# Altium schematic sheet [4] - Proximity,Temperature Sensors and OLED.SchDoc
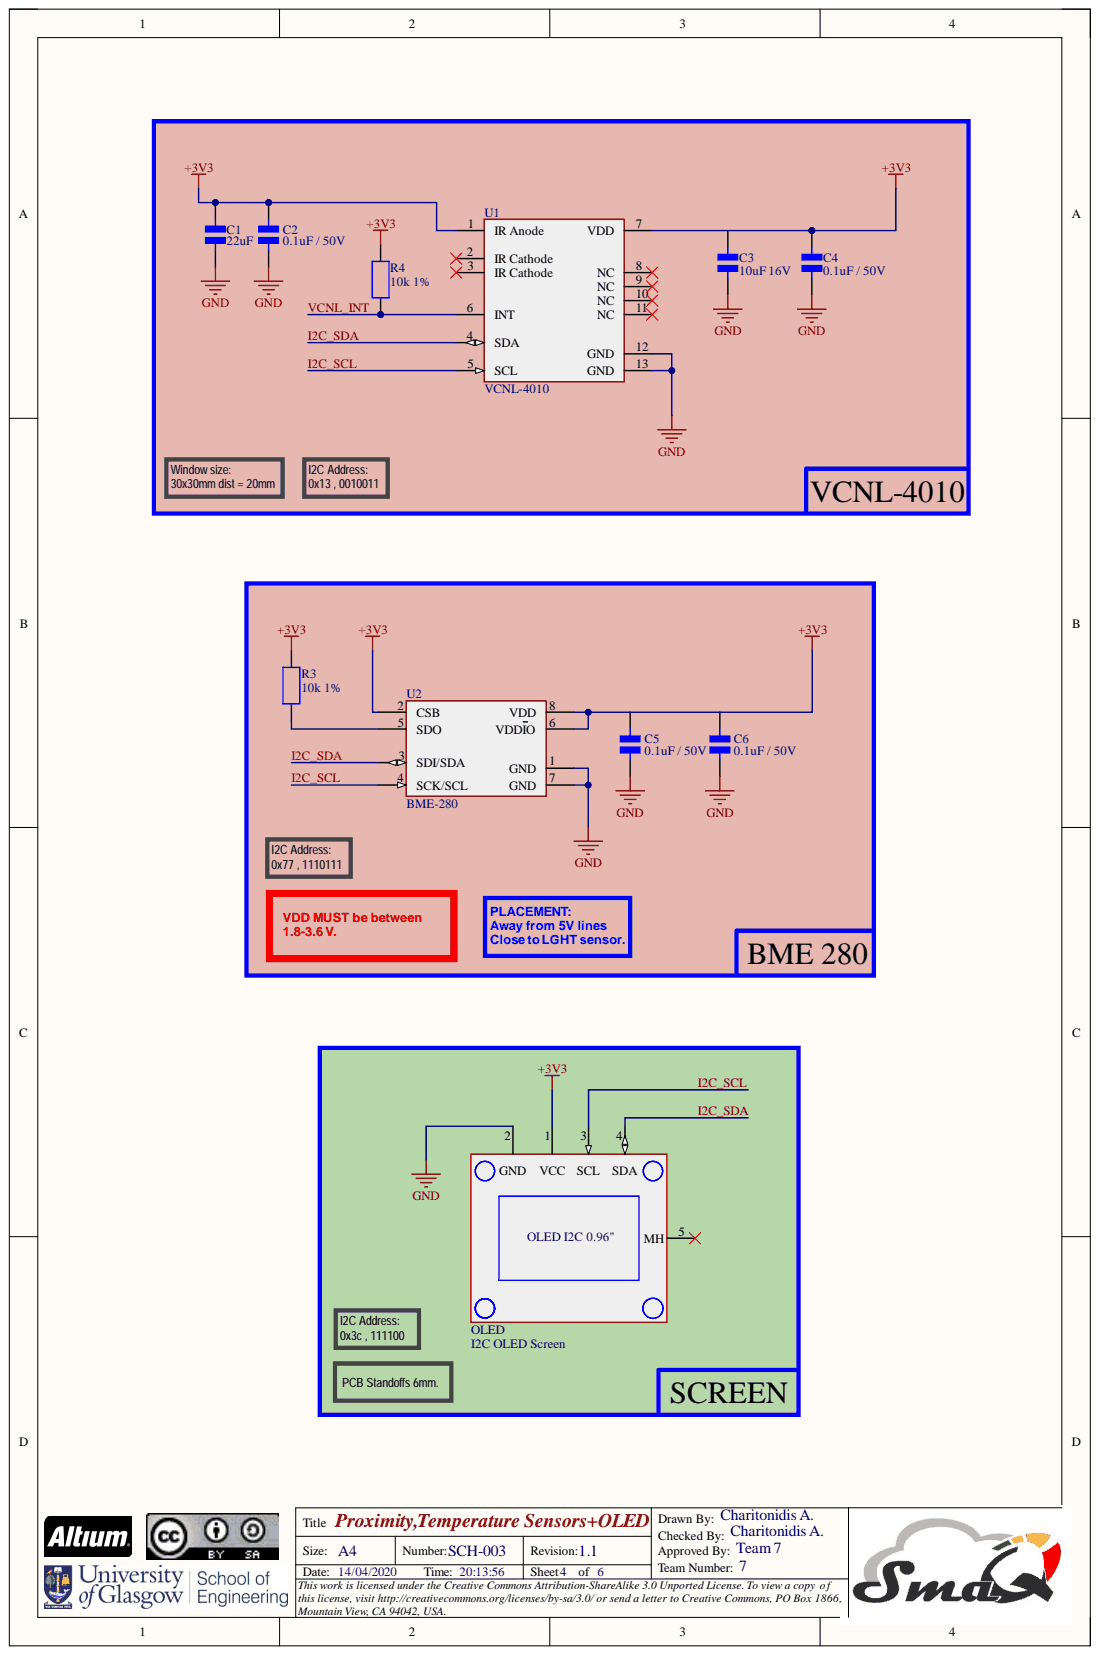
[diagram p0: [4] - Proximity,Temperature Sensors and OLED.SchDoc]

## Parts
C1  22uF  [C0805]  (no BOM)
C2  0.1uF / 50V  [C0805]  (no BOM)
C3  10uF 16V  [C0805]  (no BOM)
C4  0.1uF / 50V  [C0805]  (no BOM)
C5  0.1uF / 50V  [C0805]  (no BOM)
C6  0.1uF / 50V  Voltage Rating: 50V  [C0805]  (no BOM)
OLED  I2C OLED Screen  [OLED_I2C_0.96"]  (no BOM)
R3  10k 1%  [R0805 (2012M)]  (no BOM)
R4  10k 1%  [R0805 (2012M)]  (no BOM)
U1  VCNL-4010  [VCNL-4010]  (no BOM)
U2  BME-280  [LGA_8P_0.65MM]  (no BOM)

## Nets
+3V3: C1.2 C2.2 C4.1 OLED.1 R3.2 R4.2 U1.1(IR_Anode) U1.7(VDD) U2.2(CSB) U2.6(VDDIO) U2.8(VDD)
GND: C1.1 C2.1 C3.1 C4.2 C5.1 C6.1 OLED.2 U1.12(GND) U1.13(GND) U2.1(GND) U2.7(GND)
I2C_SCL: OLED.3 U1.5(SCL) U2.4(SCK/SCL)
I2C_SDA: OLED.4 U1.4(SDA) U2.3(SDI/SDA)
VCNL_INT: R4.1 U1.6(INT)
NetR3_1: R3.1 U2.5(SDO)

Not connected: C3 2; C5 2; C6 2; OLED 5(MH); U1 2(IR_Cathode) 3(IR_Cathode) 8(NC) 9(NC) 10(NC) 11(NC)

## Library data of the parts
C1 = C2012JB1A226M085AC: CAP CER 22UF 10V JB 0805; Manufacturer 1: TDK; Category 1: Ceramic Capacitors; Dielectric Material: Ceramic; Tolerance: 20%; Capacitance: 22uF; Case Code (Imperial): 0805; Case Code (Metric): 2012; Case/Package: 0805; ComponentLink1Description: https://datasheet.ciiva.com/14908/tdkmlcccaprange-14908199.pdf?src-supplier=Digi-Key; ComponentLink1URL: https://datasheet.ciiva.com/14908/tdkmlcccaprange-14908199.pdf?src-supplier=Digi-Key; ComponentLink2Description: https://datasheet.ciiva.com/14908/tdkmlcccaprange-14908201.pdf?src-supplier=Digi-Key; ComponentLink2URL: https://datasheet.ciiva.com/14908/tdkmlcccaprange-14908201.pdf?src-supplier=Digi-Key; Composition: Ceramic; Description 1: CAP CER 22UF 10V JB 0805; Height: 0.85mm; Lead Free: Lead Free; Length: 0.079inch; Manufacturer Part Number 1: C2012JB1A226M085AC; Max Operating Temperature: 85°C; Min Operating Temperature: -25°C; Mount: Surface Mount; Package Quantity: 1; Packaging: Tape and Reel; RoHS: Yes; Stock 1: 10381; Supplier 1: Mouser; Supplier Part Number 1: 810-C2012JB1A226M08C; Termination: SMD/SMT; Thickness: 0.039inch; Voltage Rating: 10V; Voltage Rating (DC): 10V; Width: 1.2mm; Library Name: Parts_Lib_SMAQ.SchLib
C2 C4-C6 = 08055C104JAT4A: CAP CER 0.1UF 50V X7R 0805; Manufacturer 1: Kyocera AVX; Capacitance: 100nF; Category 1: Ceramic Capacitors; Tolerance: 5%; Case Code (Imperial): 0805; Case Code (Metric): 2012; Case/Package: 0805; ComponentLink1Description: https://datasheet.ciiva.com/16326/cx7r-16326437.pdf?src-supplier=Future+Electronics; ComponentLink1URL: https://datasheet.ciiva.com/16326/cx7r-16326437.pdf?src-supplier=Future+Electronics; ComponentLink2Description: https://datasheet.ciiva.com/6277/cx7r-6277722.pdf?src-supplier=Digi-Key; ComponentLink2URL: https://datasheet.ciiva.com/6277/cx7r-6277722.pdf?src-supplier=Digi-Key; Depth: 1.25mm; Description 1: CAP CER 0.1UF 50V X7R 0805; Dielectric: X7R; Lead Free: Lead Free; Length: 2.01mm; Manufacturer Part Number 1: 08055C104JAT4A; Max Operating Temperature: 125°C; Min Operating Temperature: -55°C; Mount: Surface Mount; Number of Pins: 2; Package Quantity: 10000; Packaging: Tape and Reel; Radiation Hardening: No; RoHS: Yes; Stock 1: 70357; Supplier 1: Mouser; Supplier Part Number 1: 581-08055C104JAT4A; Termination: SMD/SMT; Thickness: 0.037inch; Voltage Rating (DC): 50V; Width: 0.049inch; Library Name: Parts_Lib_SMAQ.SchLib
  C2 C4 C5: Voltage Rating: 50V
C3 = 0805YC106MAT2A: Manufacturer 1: Kyocera AVX; Manufacturer Part Number 1: 0805YC106MAT2A; RoHS: No; Stock 1: 1756; Supplier 1: Mouser; Supplier Part Number 1: 581-0805YC106MAT2A; Library Name: Parts_Lib_SMAQ.SchLib
OLED = OLED_I2C_BREAKOUT: Library Name: Parts_Lib_SMAQ.SchLib
R3 R4 = AT0805FRE0710KL: RES SMD 10K OHM 1% 1/8W 0805; Manufacturer 1: Yageo; Category 1: Chip SMD Resistors; Max Power Dissipation: 125mW; Resistance: 10kR; Temperature Coefficient: 50ppm/°C; Case Code (Imperial): 0805; Case Code (Metric): 2012; Case/Package: 0805; ComponentLink1Description: http://www.yageo.com/documents/recent/PYu-AT_51_RoHS_L_5.pdf?src-supplier=Digi-Key; ComponentLink1URL: http://www.yageo.com/documents/recent/PYu-AT_51_RoHS_L_5.pdf?src-supplier=Digi-Key; ComponentLink2Description: http://www.yageo.com/documents/recent/PYu-AT_51_RoHS_L-3.pdf?src-supplier=Digi-Key; ComponentLink2URL: http://www.yageo.com/documents/recent/PYu-AT_51_RoHS_L-3.pdf?src-supplier=Digi-Key; Composition: Thin Film; Description 1: RES SMD 10K OHM 1% 1/8W 0805; Features: Moisture Resistant,Automotive AEC-Q200,Anti-Sulfur; Height: 0.024inch; Length: 0.079inch; Manufacturer Part Number 1: AT0805FRE0710KL; Max Operating Temperature: 155°C; Min Operating Temperature: -55°C; Number of Terminations: 2; Packaging: Tape and Reel; RoHS: Yes; Stock 1: 12821; Supplier 1: Mouser; Supplier Part Number 1: 603-AT0805FRE0710KL; Tolerance: 1%; Width: 0.049inch; Library Name: Parts_Lib_SMAQ.SchLib
U1 = VCNL4010-GS08: SENS IR PROXIMITY AMB LT 12LLP; Manufacturer 1: Vishay Semiconductors; Category 1: Sensors; Max Supply Voltage: 3.6V; Min Supply Voltage: 2.5V; Number of Channels: 1; Operating Supply Voltage: 3.3V; Output Configuration: Digital; Output Type: I2C,Digital; Power Dissipation: 50mW; Sensing Distance: 200mm; Sensing Method: Optical; Wavelength: 892nm; Case/Package: SMD/SMT; ComponentLink1Description: https://datasheet.ciiva.com/4626/vcnl4010-97030-4626352.pdf?src-supplier=Mouser; ComponentLink1URL: https://datasheet.ciiva.com/4626/vcnl4010-97030-4626352.pdf?src-supplier=Mouser; ComponentLink2Description: https://datasheet.ciiva.com/7617/vcnl4010-7617985.pdf?src-supplier=Element14; ComponentLink2URL: https://datasheet.ciiva.com/7617/vcnl4010-7617985.pdf?src-supplier=Element14; ComponentLink3Description: https://datasheet.ciiva.com/15230/vcnl4010-15230062.pdf?src-supplier=Arrow+Europe; ComponentLink3URL: https://datasheet.ciiva.com/15230/vcnl4010-15230062.pdf?src-supplier=Arrow+Europe; ComponentLink4Description: https://datasheet.ciiva.com/16225/vcnl4010-16225925.pdf?src-supplier=Future+Electronics; ComponentLink4URL: https://datasheet.ciiva.com/16225/vcnl4010-16225925.pdf?src-supplier=Future+Electronics; ComponentLink5Description: https://datasheet.ciiva.com/14650/vcnl4010-14650582.pdf?src-supplier=Element14; ComponentLink5URL: https://datasheet.ciiva.com/14650/vcnl4010-14650582.pdf?src-supplier=Element14; ComponentLink6Description: https://datasheet.ciiva.com/2819/vcnl4010-2819260.pdf?src-supplier=Digi-Key; ComponentLink6URL: https://datasheet.ciiva.com/2819/vcnl4010-2819260.pdf?src-supplier=Digi-Key; ComponentLink7Description: https://datasheet.ciiva.com/2819/vcnl4010-2819261.pdf?src-supplier=Digi-Key; ComponentLink7URL: https://datasheet.ciiva.com/2819/vcnl4010-2819261.pdf?src-supplier=Digi-Key; ComponentLink8Description: https://datasheet.ciiva.com/25912/vcnl4010-25912077.pdf?src-supplier=Avnet+Europe; ComponentLink8URL: https://datasheet.ciiva.com/25912/vcnl4010-25912077.pdf?src-supplier=Avnet+Europe; ComponentLink9Description: https://datasheet.ciiva.com/2819/vcnl4010-2819262.pdf?src-supplier=Digi-Key; ComponentLink9URL: https://datasheet.ciiva.com/2819/vcnl4010-2819262.pdf?src-supplier=Digi-Key; ComponentLink10Description: https://datasheet.ciiva.com/3275/vcnl4010-3275278.pdf?src-supplier=Avnet; ComponentLink10URL: https://datasheet.ciiva.com/3275/vcnl4010-3275278.pdf?src-supplier=Avnet; Description 1: SENS IR PROXIMITY AMB LT 12LLP; Height: 0.75mm; Lead Free: Lead Free; Length: 3.95mm; Manufacturer Part Number 1: VCNL4010-GS08; Max Operating Temperature: 85°C; Min Operating Temperature: -25°C; Mount: Surface Mount; Number of Pins: 13; Package Quantity: 1800; Packaging: Tape and Reel; Radiation Hardening: No; REACH SVHC: Unknown; RoHS: Yes; Stock 1: 15561; Supplier 1: Mouser; Supplier Part Number 1: 78-VCNL4010-GS08; Width: 3.95mm; Library Name: Parts_Lib_SMAQ.SchLib
U2 = BME280: Pressure, Temperature and Humidity Sensor Digital Output 1.8V 8-Pin LGA T/R; Manufacturer 1: Bosch; Category 1: Pressure Sensors; Case/Package: LGA; ComponentLink1Description: https://ae-bst.resource.bosch.com/media/_tech/media/product_flyer/BME280_Productflyer_BST_20170109.pdf?src-supplier=Future+Electronics; ComponentLink1URL: https://ae-bst.resource.bosch.com/media/_tech/media/product_flyer/BME280_Productflyer_BST_20170109.pdf?src-supplier=Future+Electronics; ComponentLink2Description: https://ae-bst.resource.bosch.com/media/_tech/media/datasheets/BST-BME280-DS002.pdf?src-supplier=Digi-Key; ComponentLink2URL: https://ae-bst.resource.bosch.com/media/_tech/media/datasheets/BST-BME280-DS002.pdf?src-supplier=Digi-Key; ComponentLink3Description: https://ae-bst.resource.bosch.com/media/_tech/media/product_flyer/BME280_Productflyer_BST_20170109.pdf?src-supplier=Arrow; ComponentLink3URL: https://ae-bst.resource.bosch.com/media/_tech/media/product_flyer/BME280_Productflyer_BST_20170109.pdf?src-supplier=Arrow; ComponentLink4Description: https://ae-bst.resource.bosch.com/media/_tech/media/product_flyer/BME280_Productflyer_BST_20170109.pdf?src-supplier=Mouser; ComponentLink4URL: https://ae-bst.resource.bosch.com/media/_tech/media/product_flyer/BME280_Productflyer_BST_20170109.pdf?src-supplier=Mouser; ComponentLink5Description: https://ae-bst.resource.bosch.com/media/_tech/media/product_flyer/BME280_Productflyer_BST_20170109.pdf?src-supplier=Rutronik; ComponentLink5URL: https://ae-bst.resource.bosch.com/media/_tech/media/product_flyer/BME280_Productflyer_BST_20170109.pdf?src-supplier=Rutronik; ComponentLink6Description: https://ae-bst.resource.bosch.com/media/_tech/media/product_flyer/BME280_Productflyer_BST_20170109.pdf?src-supplier=NAC+Semi; ComponentLink6URL: https://ae-bst.resource.bosch.com/media/_tech/media/product_flyer/BME280_Productflyer_BST_20170109.pdf?src-supplier=NAC+Semi; Description 1: Pressure, Temperature and Humidity Sensor Digital Output 1.8V 8-Pin LGA T/R; Lead Free: Lead Free; Manufacturer Part Number 1: BME280; Number of Pins: 8; RoHS: No; Stock 1: 22089; Supplier 1: Mouser; Supplier Part Number 1: 262-BME280; Library Name: Parts_Lib_SMAQ.SchLib
